# Altium schematic sheet STLINKv2DIY.SchDoc
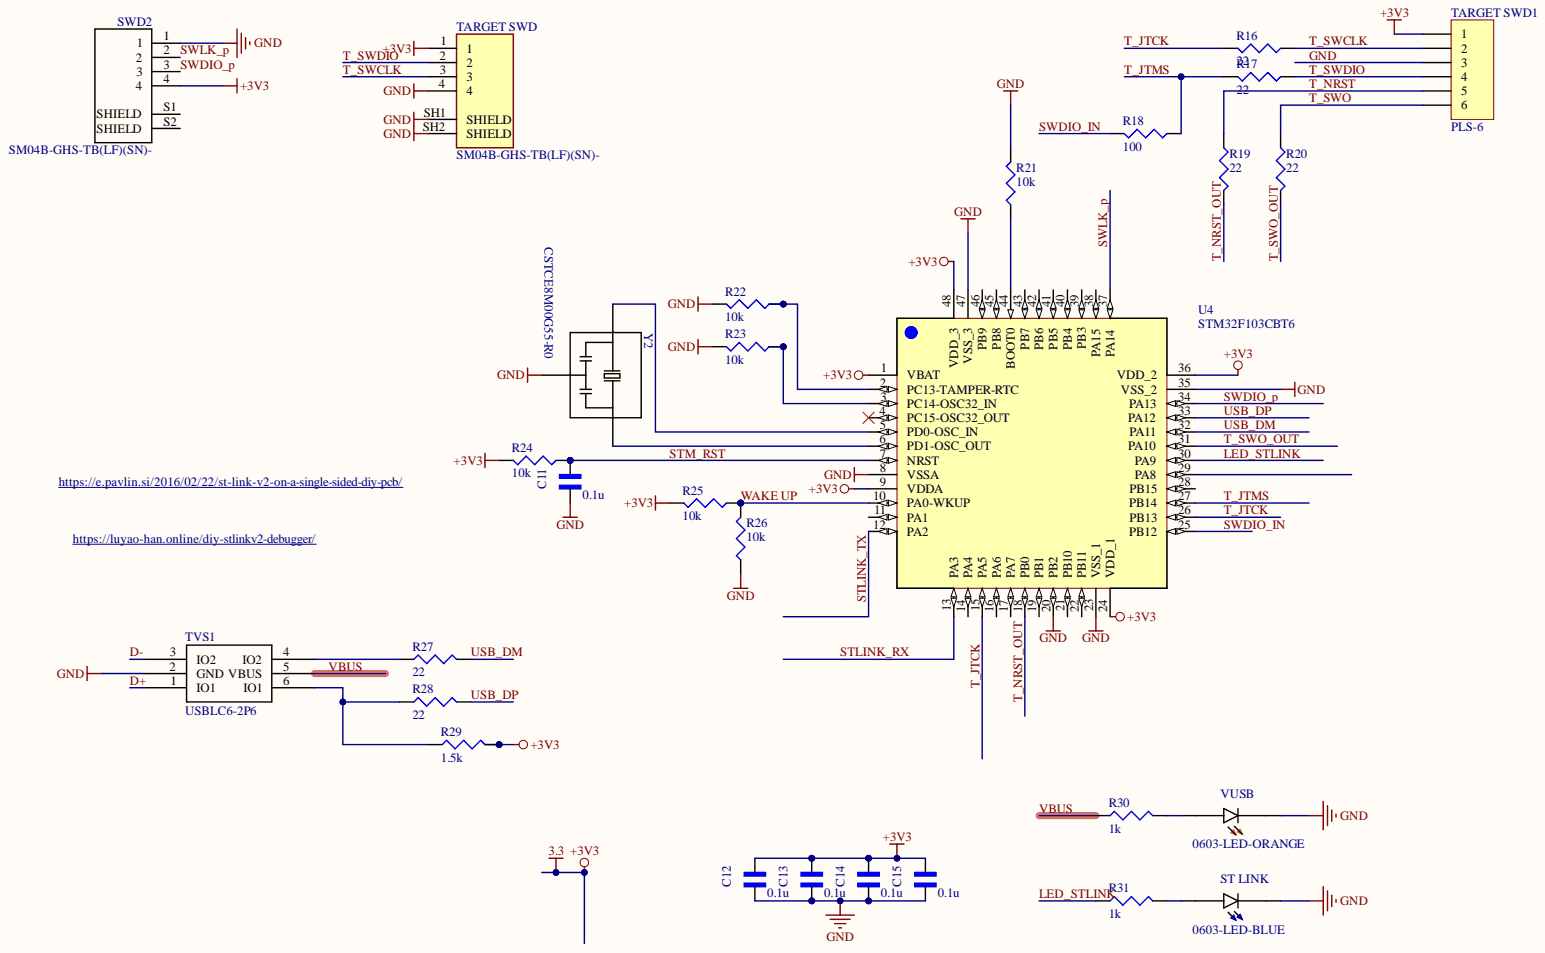
[diagram p0: STLINKv2DIY.SchDoc]

## Parts
C11  value 0.1u  [*CAPC1608X90]  (hidden comment: GX035C104MAT2-500)
C12  value 0.1u  [*CAPC1608X90]  (hidden comment: GX035C104MAT2-500)
C13  value 0.1u  [*CAPC1608X90]  (hidden comment: GX035C104MAT2-500)
C14  value 0.1u  [*CAPC1608X90]  (hidden comment: GX035C104MAT2-500)
C15  value 0.1u  [*CAPC1608X90]  (hidden comment: GX035C104MAT2-500)
R16  value 22  [J1-0603]  (hidden comment: RC0603FR-0722RL)
R17  value 22  [J1-0603]  (hidden comment: RC0603FR-0722RL)
R18  value 100  [J1-0603]  (hidden comment: RC0603FR-07100RL)
R19  value 22  [J1-0603]  (hidden comment: RC0603FR-0722RL)
R20  value 22  [J1-0603]  (hidden comment: RC0603FR-0722RL)
R21  value 10k  [J1-0603]  (hidden comment: RC0603FR-0710KL)
R22  value 10k  [J1-0603]  (hidden comment: RC0603FR-0710KL)
R23  value 10k  [J1-0603]  (hidden comment: RC0603FR-0710KL)
R24  value 10k  [J1-0603]  (hidden comment: RC0603FR-0710KL)
R25  value 10k  [J1-0603]  (hidden comment: RC0603FR-0710KL)
R26  value 10k  [J1-0603]  (hidden comment: RC0603FR-0710KL)
R27  value 22  [J1-0603]  (hidden comment: RC0603FR-0722RL)
R28  value 22  [J1-0603]  (hidden comment: RC0603FR-0722RL)
R29  value 1.5k  [J1-0603]  (hidden comment: RC0603FR-071K5L)
R30  value 1k  [J1-0603]  (hidden comment: RC0603FR-101KL)
R31  value 1k  [J1-0603]  (hidden comment: RC0603FR-101KL)
ST_LINK  0603-LED-BLUE  [*LEDM1608X80_LTE-C1906R-14(Primary)]
SWD2  SM04B-GHS-TB(LF)(SN)-  [JST_BM04B-GHS-holes]
TARGET_SWD  SM04B-GHS-TB(LF)(SN)-  [JST_SM04B-GHS-TB(LF)(SN)-]
TARGET_SWD1  PLS-6  [HDR Side 6 pin]
TVS1  USBLC6-2P6  [SOT23127P600-8N]
U4  STM32F103CBT6  [STM-LQFP48_N]
VUSB  0603-LED-ORANGE  [*LEDM1608X80_LTE-C1906R-14(Primary)]
Y2  CSTCE8M00G55-R0  [*OSC_CSTCE8M00G55-R0]

## Nets
3.3: C12.1 C13.1 C14.1 C15.1 R24.2 R25.2 R29.2 SWD2.4 TARGET_SWD.1 TARGET_SWD1.1 U4.1(VBAT) U4.9(VDDA) U4.24(VDD_1) U4.36(VDD_2) U4.48(VDD_3)
D+: TVS1.1(IO1)
D-: TVS1.3(IO2)
GND: C11.2 C12.2 C13.2 C14.2 C15.2 R21.2 R22.2 R23.2 R26.1 ST_LINK.C SWD2.1 TARGET_SWD.4 TARGET_SWD.SH1(SHIELD) TARGET_SWD.SH2(SHIELD) TARGET_SWD1.3 TVS1.2(GND) U4.8(VSSA) U4.20(PB2) U4.23(VSS_1) U4.35(VSS_2) U4.47(VSS_3) VUSB.C Y2.2
LED_STLINK: R31.1 U4.30(PA9)
STLINK_RX: U4.13(PA3)
STLINK_TX: U4.12(PA2)
STM_RST: C11.1 R24.1 U4.7(NRST)
SWDIO_IN: R18.2 U4.25(PB12)
SWDIO_p: SWD2.3 U4.34(PA13)
SWLK_p: SWD2.2 U4.37(PA14)
T_JTCK: R16.2 U4.15(PA5) U4.26(PB13)
T_JTMS: R17.2 R18.1 U4.27(PB14)
T_NRST: R19.1 TARGET_SWD1.5
T_NRST_OUT: R19.2 U4.18(PB0)
T_SWCLK: R16.1 TARGET_SWD.3 TARGET_SWD1.2
T_SWDIO: R17.1 TARGET_SWD.2 TARGET_SWD1.4
T_SWO: R20.1 TARGET_SWD1.6
T_SWO_OUT: R20.2 U4.31(PA10)
USB_DM: R27.2 U4.32(PA11)
USB_DP: R28.2 U4.33(PA12)
VBUS: R30.1 TVS1.5(VBUS)
WAKE UP: R25.1 R26.2 U4.10(PA0-WKUP)
NetR21_1: R21.1 U4.44(BOOT0)
NetR22_1: R22.1 U4.2(PC13-TAMPER-RTC)
NetR23_1: R23.1 U4.3(PC14-OSC32_IN)
NetR27_1: R27.1 TVS1.4(IO2)
NetR28_1: R28.1 R29.1 TVS1.6(IO1)
NetR30_2: R30.2 VUSB.A
NetR31_2: R31.2 ST_LINK.A
NetU4_5: U4.5(PD0-OSC_IN) Y2.1
NetU4_6: U4.6(PD1-OSC_OUT) Y2.3

Not connected: SWD2 S1(SHIELD) S2(SHIELD); U4 4(PC15-OSC32_OUT) 11(PA1) 14(PA4) 16(PA6) 17(PA7) 19(PB1) 21(PB10) 22(PB11) 28(PB15) 29(PA8) 38(PA15) 39(PB3) 40(PB4) 41(PB5) 42(PB6) 43(PB7) 45(PB8) 46(PB9)

## Library data of the parts
C11-C15 = GX035C104MAT2-500: Cap Thin Film 0.1uf 50v 0603; MF: AVX; MP: GX035C104MAT2-500 or CL10B104JB8NNNC; Pacage Metric: 1608; Type: SMD; Component Kind: Standard; Library Name: lib.SchLib; Package: 0603 AVX; SnapEDA_Link: https://www.snapeda.com/parts/GX035C104MAT2-500/AVX/view-part/?ref=snap
R16 R17 R19 R20 R27 R28 = RC0603FR-0722RL: 22 Ohms ±1% 0.1W, 1/10W Chip Resistor 0603 (1608 Metric) Moisture Resistant Thick Film; MF: Yageo or NXP; Pacage Metric: 1608; Component Kind: Standard; Library Name: lib.SchLib; MP: RC0603FR-0722RL; Package: R0603; Signal Integrity: Res; Simulation: RESISTOR; SnapEDA_Link: https://www.snapeda.com/parts/RC0603FR-0722RL/Mepco%20Philips/view-part/?ref=search&t=RC0603FR-0722RL; Type: SMD
R18 = RC0603FR-07100RL: 100 Ohms ±1% 0.1W, 1/10W Chip Resistor 0603 (1608 Metric) Thick Film; MF: Yageo or NXP; Pacage Metric: 1608; Component Kind: Standard; Library Name: lib.SchLib; MP: RC0603FR-07100RL; Package: R0603; Signal Integrity: Res; Simulation: RESISTOR; SnapEDA_Link: https://www.snapeda.com/parts/RC0603FR-07100RL/Yageo/view-part/?ref=search&t=RC0603FR-07100RL; Type: SMD
R21-R26 = RC0603FR-0710KL: 10 kOhms 1 0.1 W 1 10 W Chip Resistor 0603 1608 Metric Thick Film; MF: Yageo or NXP; MP: 10K 1% 0603; Pacage Metric: 1608; ChipDip_Link: https://www.chipdip.ru/product0/9000079736; Component Kind: Standard; HelpURL: https://www.chipdip.ru/product0/9000079736; Library Name: lib.SchLib; Package: R0603; Signal Integrity: Res; Simulation: RESISTOR; SnapEDA_Link: https://www.snapeda.com/parts/RC0603FR-0710KL/Yageo/view-part/?ref=dk&t=RC0603FR-0710KL&con_ref=None; Type: SMD
R29 = RC0603FR-071K5L: 1.5 kOhms ±1% 0.1W, 1/10W Chip Resistor 0603 (1608 Metric) Thick Film; MF: Yageo or NXP; Pacage Metric: 1608; Component Kind: Standard; Library Name: lib.SchLib; MP: RC0603FR-071K5L; Package: R0603; Signal Integrity: Res; Simulation: RESISTOR; SnapEDA_Link: https://www.snapeda.com/parts/RC0603FR-071K5L/Yageo/view-part/?ref=search&t=RC0603FR-07%201k; Type: SMD
R30 R31 = RC0603FR-101KL: 1 kOhms ±1% 0.1W, 1/10W Chip Resistor 0603 (1608 Metric) Moisture Resistant Thick Film; MF: Yageo or NXP; Pacage Metric: 1608; Component Kind: Standard; Library Name: lib.SchLib; MP: RC0603FR-101KL; Package: R0603; Signal Integrity: Res; Simulation: RESISTOR; SnapEDA_Link: https://www.snapeda.com/parts/RC0603FR-101KL/Yageo/view-part/?ref=search&t=RC0603FR-07%201k; Type: SMD
ST_LINK = 0603-LED-BLUE: LED IrLED Chip LED 2-Pin SMD T/R; MP: LTST-S270TBKT or  LTST-C194TBKT or LTST-C191TBKT or  SML-D12D1WT86; Component Kind: Standard; Library Name: lib.SchLib; Package: 0603 Lite-On; SnapEDA_Link: https://www.snapeda.com/parts/LTE-C1906R-14/Lite-On%20Inc./view-part/?ref=snap; Type: SMD
SWD2 = SWD SM04B-GHS-TB(LF)(SN)-: Conn Shrouded Header HDR 4 POS 1.25mm Solder ST SMD Reel; Instructions: Do not install; MF: JST Sales; System: Connector; Component Kind: Standard; Library Name: lib.SchLib; MP: SM04B-GHS-TB(LF)(SN); Package: None; SnapEDA_Link: https://www.snapeda.com/parts/BM04B-GHS-TBT%28LF%29%28SN%29%28N%29/JST%20Sales%20America%20Inc./view-part/?ref=snap; Type: SMD
TARGET_SWD = SM04B-GHS-TB(LF)(SN)-: Connector Header Surface Mount, Right Angle 4 position 0.049 _1.25mm_; MF: JST Sales; ChipDip_Link: https://www.chipdip.ru/product/sm04b-ghs-tb-lf-sn-gh-1.25mm-header-side-ent; Component Kind: Standard; HelpURL: http://www.farnell.com/datasheets/2082975.pdf; Library Name: lib.SchLib; MP: SM04B-GHS-TB(LF)(SN); Package: None; SnapEDA_Link: https://www.snapeda.com/parts/SM04B-GHS-TB%28LF%29%28SN%29-/JST%20Sales%20America%20Inc./view-part/2759740/?ref=snap; System: Connector
TARGET_SWD1 = PLS-6: PLS-6 (DS1021-1x6), Вилка штыревая 2.54мм 1x6 прямая тип1; Instructions: DNP; System: Connector; ChipDip_Link: https://www.chipdip.ru/product/pls-6; Component Kind: Standard; Library Name: lib.SchLib; MP: PLS-6; Signal Integrity: Connector; Type: SMD
TVS1 = USBLC6-2P6: 17V Clamp 5A (8/20µs) Ipp Tvs Diode Surface Mount SOT-666; MF: STM; ChipDip_Link: https://www.chipdip.ru/product/usblc6-2p6-2; Component Kind: Standard; HelpURL: https://static.chipdip.ru/lib/093/DOC001093117.pdf; Library Name: lib.SchLib; MP: USBLC6-2P6; Package: SOT-666; SnapEDA_Link: https://www.snapeda.com/parts/USBLC6-2P6/STMicroelectronics/view-part/?ref=search&t=USBLC6-2P6; Type: SMD
U4 = STM32F103CBT6: ARM® Cortex®-M3 STM32F1 Microcontroller IC 32-Bit 72MHz 128KB (128K x 8) FLASH; MF: STM; ChipDip_Link: https://www.chipdip.ru/product/stm32f103cbt6; Component Kind: Standard; HelpURL: https://static.chipdip.ru/lib/235/DOC000235733.pdf; Library Name: lib.SchLib; MP: STM32F103CBT6; Package: LQFP-48; SnapEDA_Link: https://www.snapeda.com/parts/STM32F103CBT6/STMicroelectronics/view-part/?ref=search&t=STM32F103CBT6; Type: SMD
VUSB = 0603-LED-ORANGE: Orange 605nm LED Indication - Discrete 2.2V 0603 (1608 Metric); MP: SML-D12D1WT86 or LTST-C194KFKT; Component Kind: Standard; Library Name: lib.SchLib; Package: 0603 Lite-On; SnapEDA_Link: https://www.snapeda.com/parts/SML-D12D1WT86/Rohm/view-part/?ref=search&t=0603%20LED%20orange; Type: SMD
Y2 = CSTCE8M00G55-R0: Ceramic Resonator 8MHz ??0.5% _Tol_ ??0.2% _Stability_ 33pF 40Ohm 3-Pin CSMD T/R; MF: Murata; ChipDip_Link: https://www.chipdip.ru/product1/8001783721; Component Kind: Standard; HelpURL: https://www.murata.com/en-eu/api/pdfdownloadapi?cate=&partno=CSTCE8M00G55-R0; Library Name: lib.SchLib; MP: CSTCE8M00G55-R0; Package: SMD-3 Murata; SnapEDA_Link: https://www.snapeda.com/parts/CSTCE8M00G55-R0/Murata%20Electronics%20North%20America/view-part/?ref=snap; Type: SMD
